# Altium schematic sheet ST_LINK_V2-1.SchDoc
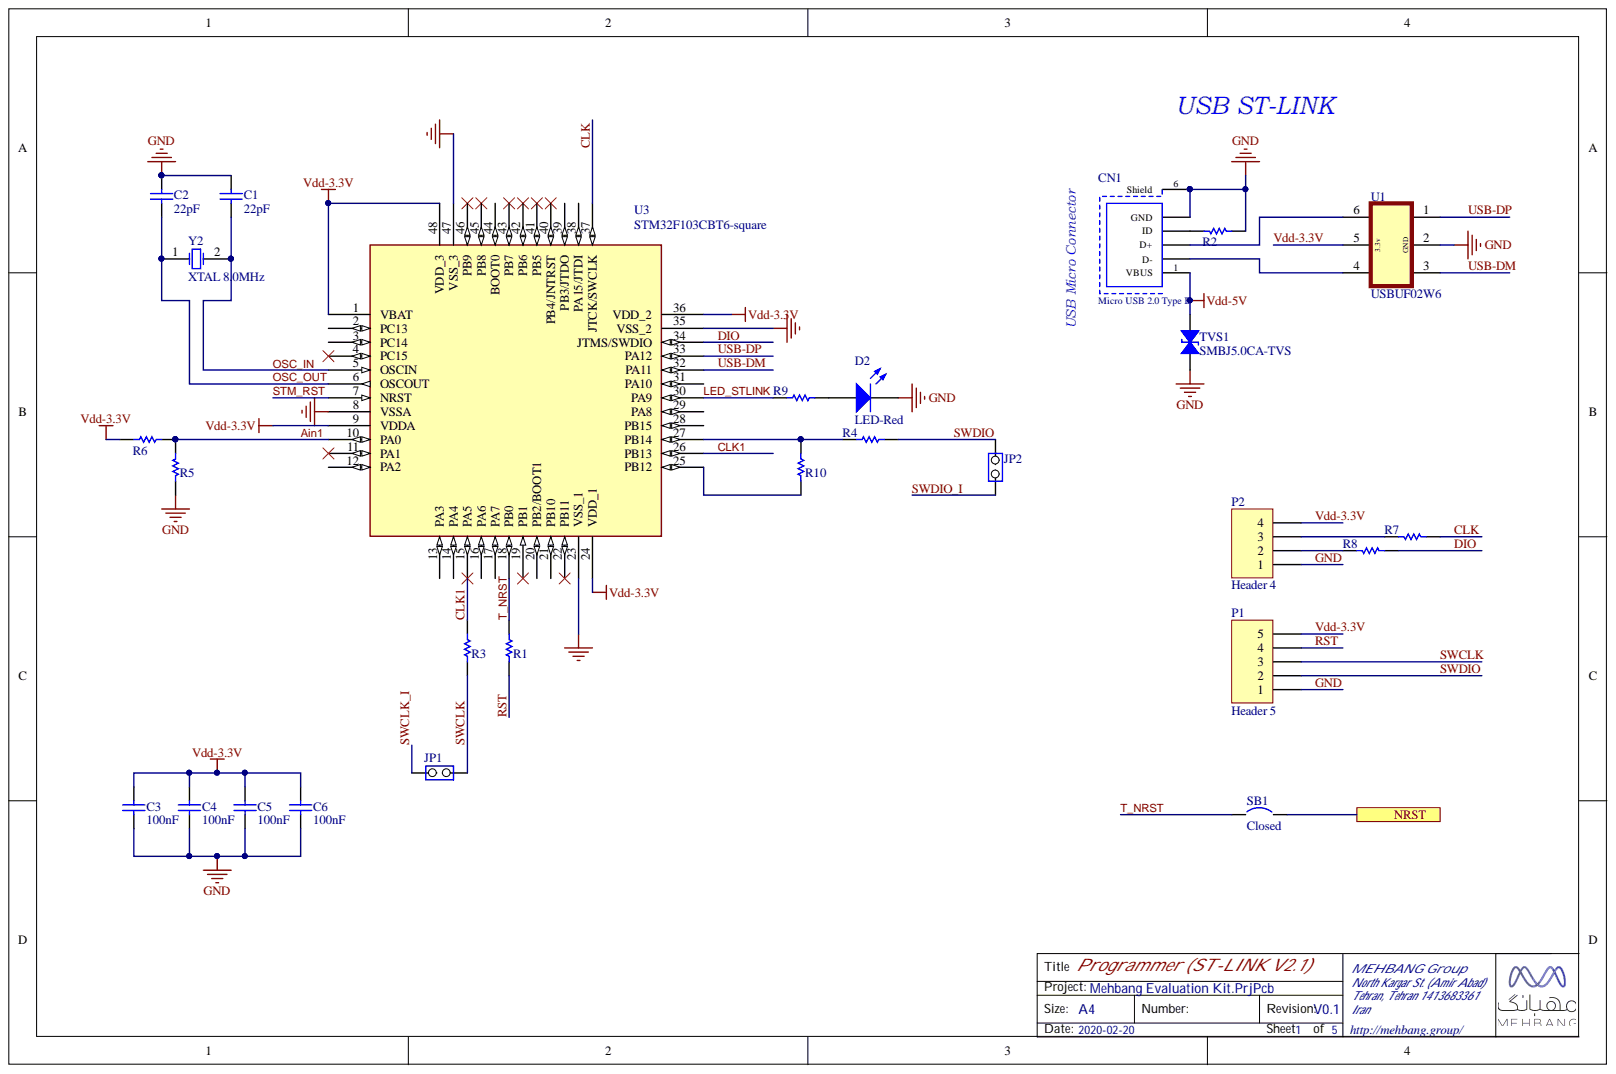
[diagram p0: ST_LINK_V2-1.SchDoc]

## Parts
C1  22pF  [0805-Cap]
C2  22pF  [0805-Cap]
C3  100nF  [0805-Cap]
C4  100nF  [0805-Cap]
C5  100nF  [0805-Cap]
C6  100nF  [0805-Cap]
CN1  Micro USB 2.0 Type B  Application: Micro USB 2.0  Interface Type: Type B  [USB Micro Type-AB Female RA]
D2  LED-Red  [0805-LED]
JP1  (no value)  [SIP2/2.54]
JP2  (no value)  [SIP2/2.54]
P1  Header 5  [header 5x1]
P2  Header 4  [HDR1X4]
R1  22  [0805-Res]  (value not drawn)
R2  100K  [0805-Res]  (value not drawn)
R3  22  [0805-Res]  (value not drawn)
R4  22  [0805-Res]  (value not drawn)
R5  4.7K  [0805-Res]  (value not drawn)
R6  4.7K  [0805-Res]  (value not drawn)
R7  22  [0805-Res]  (value not drawn)
R8  22  [0805-Res]  (value not drawn)
R9  470  [0805-Res]  (value not drawn)
R10  100  [0805-Res]  (value not drawn)
SB1  Closed  [SB_0603]
TVS1  SMBJ5.0CA-TVS  [TVS]
U1  USBUF02W6  [USBUF02W6-l]
U3  STM32F103CBT6-square  [QFP48 7X7]
Y2  XTAL 8.0MHz  [XTAL-SMD]

## Ports
NRST

## Nets
Vdd-5V: CN1.1(VBUS) TVS1.1
Ain1: R5.1 R6.1 U3.10(PA0)
CLK: R7.1 U3.37(JTCK/SWCLK)
CLK1: R3.2 U3.15(PA5) U3.26(PB13)
DIO: R8.1 U3.34(JTMS/SWDIO)
GND: C1.1 C2.2 C3.2 C4.2 C5.1 C6.2 CN1.6(Shield) CN1.G(GND) D2.2 P1.1 P2.1 R2.2 R5.2 TVS1.2 U1.2 U3.8(VSSA) U3.23(VSS_1) U3.35(VSS_2) U3.47(VSS_3)
LED_STLINK: R9.2 U3.30(PA9)
NRST: SB1.2 ; port NRST
OSC_IN: C1.2 U3.5(OSCIN) Y2.2
OSC_OUT: C2.1 U3.6(OSCOUT) Y2.1
RST: P1.4 R1.1
STM_RST: U3.7(NRST)
SWCLK: JP1.1 P1.3 R3.1
SWCLK_I: JP1.2
SWDIO: JP2.1 P1.2 R4.1
SWDIO_I: JP2.2
T_NRST: R1.2 SB1.1 U3.18(PB0)
USB-DM: U1.3 U3.32(PA11)
USB-DP: U1.1 U3.33(PA12)
Vdd-3.3V: C3.1 C4.1 C5.2 C6.1 P1.5 P2.4 R6.2 U1.5 U3.1(VBAT) U3.9(VDDA) U3.24(VDD_1) U3.36(VDD_2) U3.48(VDD_3)
NetCN1_D+: CN1.D+ U1.6
NetCN1_D-: CN1.D- U1.4
NetCN1_ID: CN1.ID R2.1
NetD2_1: D2.1 R9.1
NetP2_2: P2.2 R8.2
NetP2_3: P2.3 R7.2
NetR4_2: R4.2 R10.1 U3.27(PB14)
NetR10_2: R10.2 U3.25(PB12)

Not connected: U3 2(PC13) 3(PC14) 4(PC15) 11(PA1) 12(PA2) 13(PA3) 14(PA4) 16(PA6) 17(PA7) 19(PB1) 20(PB2/BOOT1) 21(PB10) 22(PB11) 28(PB15) 29(PA8) 31(PA10) 38(PA15/JTDI) 39(PB3/JTDO) 40(PB4/JNTRST) 41(PB5) 42(PB6) 43(PB7) 44(BOOT0) 45(PB8) 46(PB9)

## Library data of the parts
C1-C6 = Cap: Capacitor; Component Kind: Standard; LatestRevisionDate: 17-Jul-2002; LatestRevisionNote: Re-released for DXP Platform.; Library Name: DaEvKit-LIB.IntLib; PackageDescription: Radial Cap, Thru-Hole; 2 Leads; 0.3 in Pin Spacing; PackageReference: RAD-0.3; Published: 8-Jun-2000; Publisher: Altium Limited
CN1 = USB Micro Type-AB Female RA: Micro USB 2.0 Type AB; Part Number: 629105136821; Gender: Receptacle; Pin Number: 5; Rated Current: 1A; Working Voltage: 30VAC; Component Kind: Standard; ComponentLink1Description: Manufacturer; ComponentLink1URL: http://www.we-online.de; ComponentLink2Description: Datasheet; ComponentLink2URL: https://katalog.we-online.com/em/datasheet/629105136821.pdf; Library Name: DaEvKit-LIB.IntLib; Mounting Technology: SMT; Operating Temperature: -40 to +105degC; Packaging: Tape and Reel; Published Date: September 2019; Type: Horizontal; Publisher: Wurth Elektronik
D2 = LED: Typical RED, GREEN, YELLOW, AMBER GaAs LED; Component Kind: Standard; LatestRevisionDate: 17-Jul-2002; LatestRevisionNote: Re-released for DXP Platform.; Library Name: DaEvKit-LIB.IntLib; PackageDescription: SM LED; 2 Flat Leads; PackageReference: 3.2X1.6X1.1; PackageVersion: Feb-2002; Published: 8-Jun-2000; Publisher: Altium Limited
JP1 JP2 = JP2: Component Kind: Standard
P1 = Header 5: Header, 5-Pin; Component Kind: Standard; LatestRevisionDate: 17-Jul-2002; LatestRevisionNote: Re-released for DXP Platform.; Library Name: DaEvKit-LIB.IntLib; Publisher: Altium Limited
P2 = Header 4: Header, 4-Pin; Component Kind: Standard; LatestRevisionDate: 17-Jul-2002; LatestRevisionNote: Re-released for DXP Platform.; Library Name: DaEvKit-LIB.IntLib; Publisher: Altium Limited
R1-R10 = Res: Resistor; Code_IPC: 2012 [0805]; Component Kind: Standard; ComponentLink1Description: Manufacturer Link; ComponentLink1URL: http://www.panasonic.com/industrial/components/resistive_products.htm; ComponentLink2Description: Datasheet; ComponentLink2URL: http://www.panasonic.com/industrial/components/pdf/AOF0000CE1.pdf; LatestRevisionNote: Silkscreen size reduced.; Library Name: DaEvKit-LIB.IntLib; Publisher: Altium Limited
SB1 = Circuit Breaker: Component Kind: Standard; Description: Circuit Breaker; Library Name: DaEvKit-LIB.IntLib; Published: 24-Mar-1999; Revision: July-2002: Re-released for DXP Platform.; Publisher: Altium Limited
TVS1 = Diode TVS: Zener Diode; Component Kind: Standard; Library Name: DaEvKit-LIB.IntLib
U1 = UU2: Component Kind: Standard; Library Name: DaEvKit-LIB.IntLib
U3 = STM32F103CBT6-square: Mainstream Performance line, ARM Cortex-M3 MCU with 64 Kbytes Flash, 72 MHz CPU, motor control, USB and CAN; Manuf_1: STM; Manuf_Part_1: STM32F103C8T6; ECCN_US/EU: 3A991A2/NEC; Provided: ST; Ref St: 9175981; Component Kind: Standard; Distrib: ST; Library Name: DaEvKit-LIB.IntLib; Order: STM32F103C8T6
Y2 = XTAL: Crystal Oscillator; Component Kind: Standard; LatestRevisionDate: 17-Jul-2002; LatestRevisionNote: Re-released for DXP Platform.; Library Name: DaEvKit-LIB.IntLib; PackageDescription: Crystal, Thru-Hole; 2 Leads; Body 3.1 x 8.2 mm (Dia.xH); PackageReference: R38; Published: 8-Jun-2000; Publisher: Altium Limited
